# Altium schematic sheet NEO7M_EVAL.SchDoc
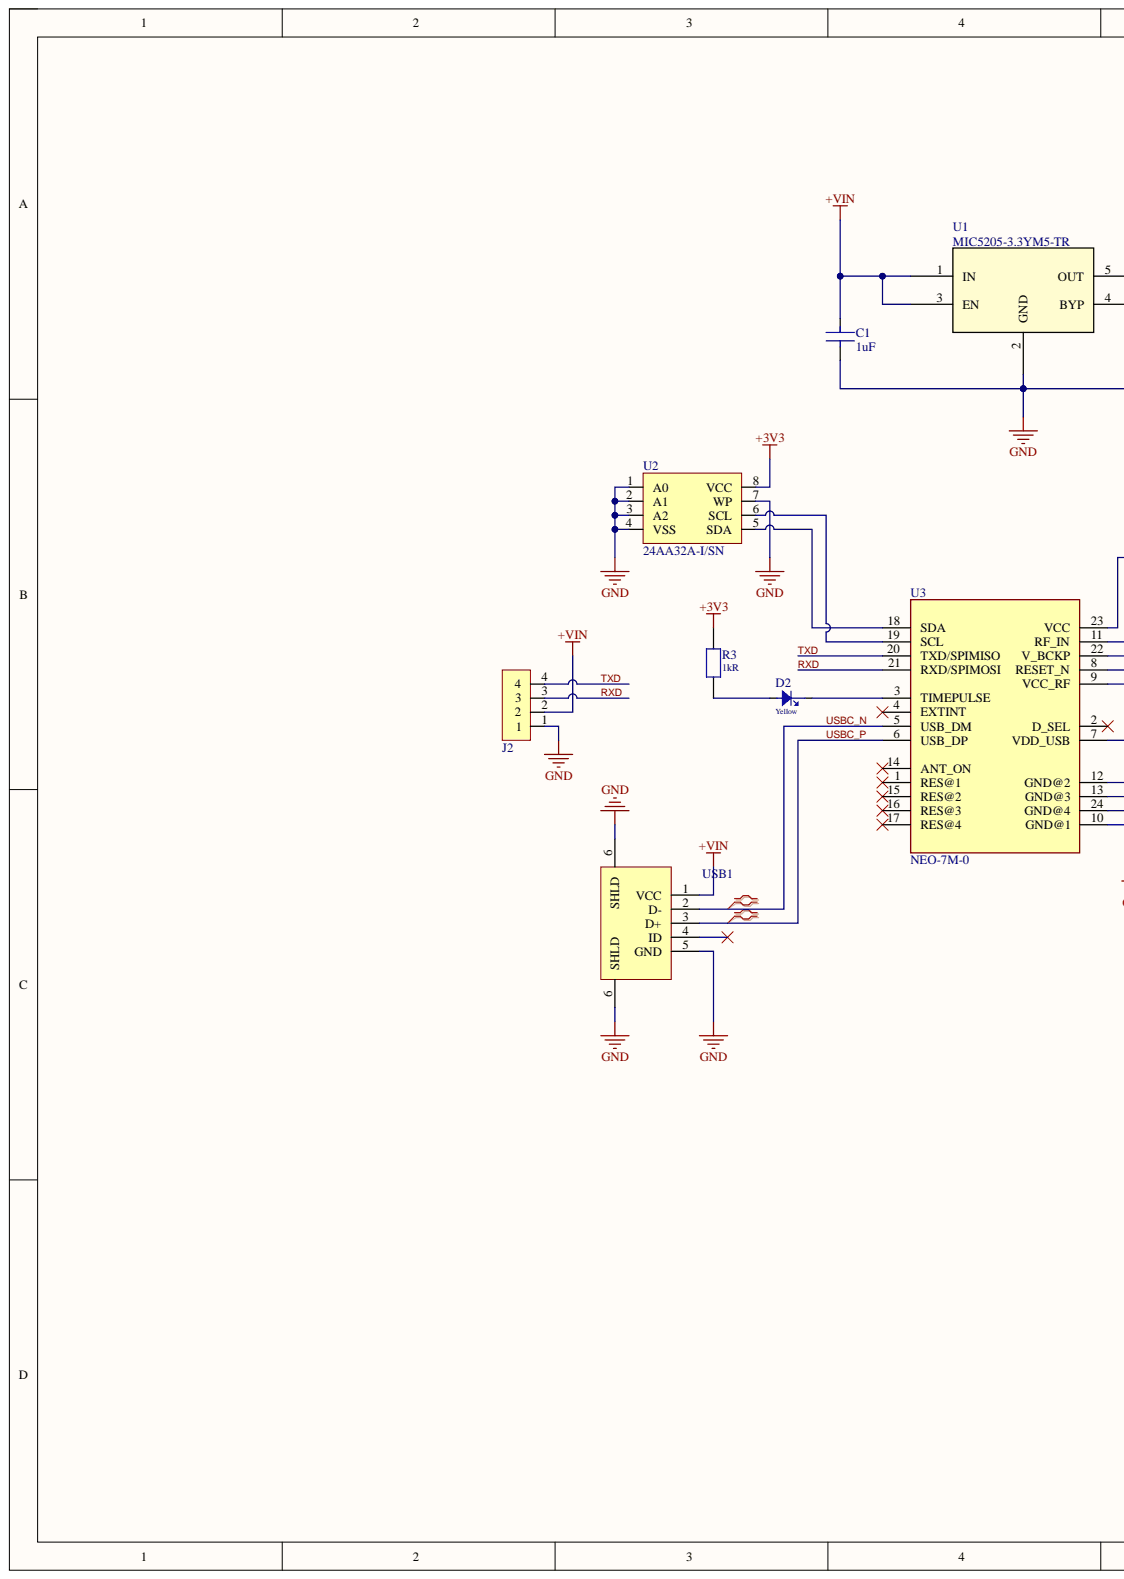
[diagram p0: NEO7M_EVAL.SchDoc, left]
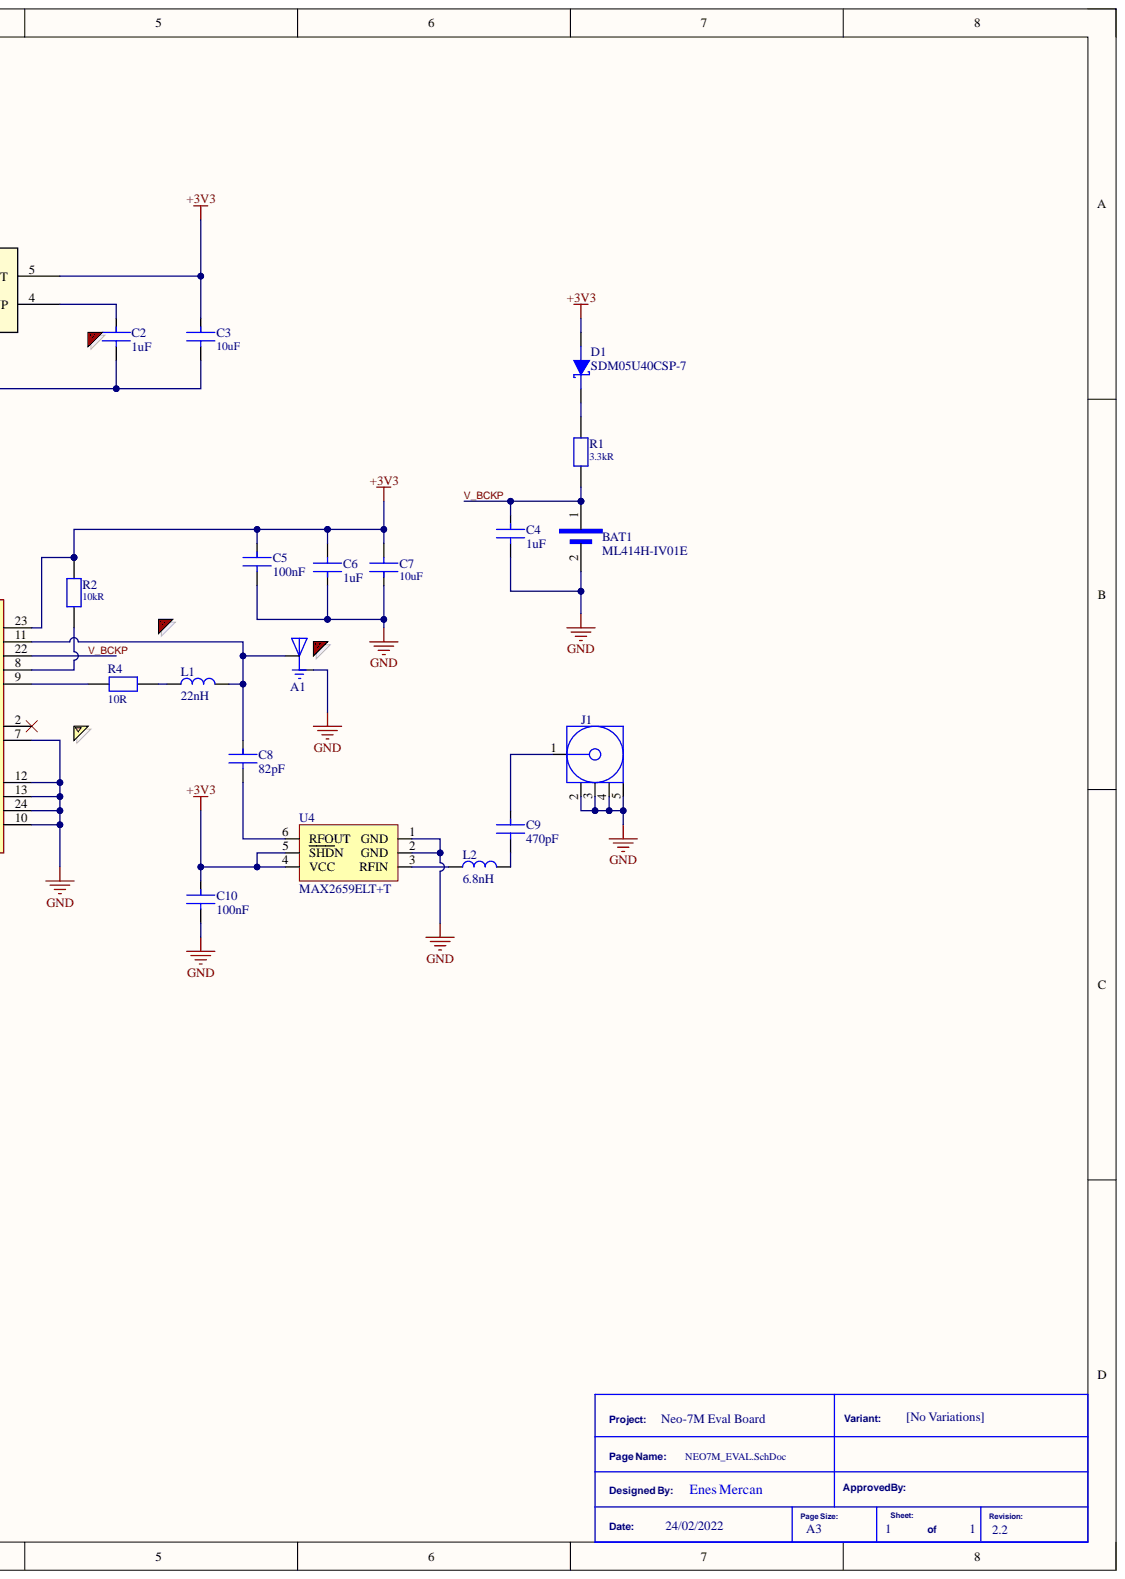
[diagram p1: NEO7M_EVAL.SchDoc, right]

## Parts
A1  U.FL-R-SMT-1(10)  [HRS_U.FL-R-SMT-1(10)]  (value not drawn)
BAT1  ML414H-IV01E  [ML414H-IV01E]
C1  Capacitance: 1uF  [CAP CER 0805]  (hidden comment: CL21B105KOFNFNE)
C2  Capacitance: 1uF  [CAP CER 0805]  (hidden comment: CL21B105KOFNFNE)
C3  Capacitance: 10uF  [CAP CER 0805]  (hidden comment: GRM21BR61E106MA73L)
C4  Capacitance: 1uF  [CAP CER 0805]  (hidden comment: CL21B105KOFNFNE)
C5  Capacitance: 100nF  [CAP CER 0603]  (hidden comment: C0603C104K5RAC7082)
C6  Capacitance: 1uF  [CAP CER 0805]  (hidden comment: CL21B105KOFNFNE)
C7  Capacitance: 10uF  [CAP CER 0805]  (hidden comment: GRM21BR61E106MA73L)
C8  Capacitance: 82pF  [CAP CER 0603]  (hidden comment: 06035A820FAT2A)
C9  value 470pF  [CAP CER 0603]  (hidden comment: C0603C471F8HAC7867)
C10  Capacitance: 100nF  [CAP CER 0603]  (hidden comment: C0603C104K5RAC7082)
D1  SDM05U40CSP-7  [SDM05U40CSP-7]
D2  Color: Yellow  [LED GR 0603]  (hidden comment: APTD1608LSYCK/J3-PF)
J1  60311002114501  [CONN SMA]  (value not drawn)
J2  M20-9730445  [HDR_ML_1X4]  (value not drawn)
L1  Inductance: 22nH  [IND 0603]  (hidden comment: LQW18AN22NG00D)
L2  Inductance: 6.8nH  [IND 0402]  (hidden comment: L-07C6N8JV6T)
R1  Resistance: 3.3kR  [RES 0603]  (hidden comment: RC0603FR-073K3L)
R2  Resistance: 10kR  [RES 0603]  (hidden comment: RC0603FR-0710KL)
R3  Resistance: 1kR  [RES 0603]  (hidden comment: RC0603FR-071KL)
R4  Resistance: 10R  [Res 0402]  (hidden comment: RK73H1ERTTP10R0F)
U1  MIC5205-3.3YM5-TR  [SOT-23-5]
U2  24AA32A-I/SN  [SOIC-8]
U3  NEO-7M-0  [NEO-7M-0]
U4  MAX2659ELT+T  [6-WFDFN]
USB1  10103592-0001LF  [10103592-0001LF]  (value not drawn)

## Nets
+3V3: C3.2 C5.2 C6.1 C7.1 C10.2 D1.A R2.2 R3.2 U1.5(OUT) U2.8(VCC) U3.23(VCC) U4.4(VCC) U4.5(SHDN)
+VIN: C1.2 J2.2 U1.1(IN) U1.3(EN) USB1.1(VCC)
GND: A1.GND BAT1.2 C1.1 C2.1 C3.1 C4.1 C5.1 C6.2 C7.2 C10.1 J1.2 J1.3 J1.4 J1.5 J2.1 U1.2(GND) U2.1(A0) U2.2(A1) U2.3(A2) U2.4(VSS) U2.7(WP) U3.7(VDD_USB) U3.10(GND@1) U3.12(GND@2) U3.13(GND@3) U3.24(GND@4) U4.1(GND) U4.2(GND) USB1.5(GND) USB1.6(SHLD)
RXD: J2.3 U3.21(RXD/SPIMOSI)
TXD: J2.4 U3.20(TXD/SPIMISO)
USBC_N: U3.5(USB_DM) USB1.2(D-)
USBC_P: U3.6(USB_DP) USB1.3(D+)
V_BCKP: BAT1.1 C4.2 R1.1 U3.22(V_BCKP)
NetA1_SIG: A1.SIG C8.2 L1.2 U3.11(RF_IN)
NetC2_2: C2.2 U1.4(BYP)
NetC8_1: C8.1 U4.6(RFOUT)
NetC9_1: C9.1 L2.1
NetC9_2: C9.2 J1.1
NetD1_C: D1.C R1.2
NetD2_1: D2.1 U3.3(TIMEPULSE)
NetD2_2: D2.2 R3.1
NetL1_1: L1.1 R4.1
NetL2_2: L2.2 U4.3(RFIN)
NetR2_1: R2.1 U3.8(RESET_N)
NetR4_2: R4.2 U3.9(VCC_RF)
NetU2_5: U2.5(SDA) U3.18(SDA)
NetU2_6: U2.6(SCL) U3.19(SCL)

Not connected: U3 1(RES@1) 2(D_SEL) 4(EXTINT) 14(ANT_ON) 15(RES@2) 16(RES@3) 17(RES@4); USB1 4(ID)

## Library data of the parts
A1 = U.FL-R-SMT-1(10): HIROSE(HRS) - U.FL-R-SMT-1(10) - RF COAXIAL, U.FL, STRAIGHT JACK, 50OHM; Body Material: Bronze; Body Plating: Silver; Connector Type: Coaxial,Receptacle,Male Pin; Dielectric Material: Polymer; Frequency: 6GHz; Gender: Male; Impedance: 50R; Insulation Resistance: 500MR; Category: RF / Coaxial Connectors; Component Kind: Standard; ComponentLink1Description: https://datasheet.ciiva.com/11762/0900766b8105243f-11762474.pdf?src-supplier=RSComponents; ComponentLink1URL: https://datasheet.ciiva.com/11762/0900766b8105243f-11762474.pdf?src-supplier=RSComponents; ComponentLink2Description: https://datasheet.ciiva.com/7651/91524-7651914.pdf?src-supplier=Element14; ComponentLink2URL: https://datasheet.ciiva.com/7651/91524-7651914.pdf?src-supplier=Element14; ComponentLink3Description: https://datasheet.ciiva.com/7651/91524-7651915.pdf?src-supplier=Element14; ComponentLink3URL: https://datasheet.ciiva.com/7651/91524-7651915.pdf?src-supplier=Element14; Contact Material: Brass,Bronze; Contact Plating: Gold; Depth: 3.1mm; Fastening Type: Snap; Housing Color: Beige; Lead Free: Lead Free; Length: 3mm; Library Name: MyLib.IntLib; Manufacturer: Hirose; Manufacturer Part Number: U.FL-R-SMT-1(10); Max Frequency: 6GHz; Max Operating Temperature: 90°C; Min Operating Temperature: -40°C; Mount: Surface Mount,Through Hole,PCB; Orientation: Straight; Package Quantity: 2500; Packaging: Tape and Reel; Pricing 1: 2500=0.62974, 5000=0.60802, 12500=0.56459 (USD); Radiation Hardening: No; REACH SVHC: No SVHC; RoHS: Yes; Stock 1: 24998; Supplier 1: Digi-Key; Supplier Part Number 1: H11891TR-ND; Termination: Solder; Wire/Cable Type: Coaxial
BAT1 = ML414H-IV01E: BATTERY LITHIUM 3V RECHARGE; Manufacturer: Seiko; Category: Batteries and Accessories; Component Kind: Standard; ComponentLink1Description: https://datasheet.ciiva.com/3/ml414h-e-3633.pdf?src-supplier=Digi-Key; ComponentLink1URL: https://datasheet.ciiva.com/3/ml414h-e-3633.pdf?src-supplier=Digi-Key; ComponentLink2Description: https://datasheet.ciiva.com/3/ml414h-e-3634.pdf?src-supplier=Digi-Key; ComponentLink2URL: https://datasheet.ciiva.com/3/ml414h-e-3634.pdf?src-supplier=Digi-Key; Library Name: My_SCH_Lib.SchLib; Manufacturer Part Number: ML414H-IV01E; Package Quantity: 1; Packaging: Tape and Reel; Pricing 1: 1=1.82000, 10=1.63000, 50=1.45000, 100=1.27000, 1000=0.96600, 10000=0.81500 (USD); RoHS: Yes; Stock 1: 5581; Supplier 1: Mouser; Supplier Part Number 1: 628-ML414H-IV01E; Termination: SMD/SMT; Voltage Rating: 3V; Weight: 0.007
C1 C2 C4 C6 = CAP CER 1UF 16V 0805: CAP CER 1UF 16V X7R 0805; Manufacturer: Samsung; Tolerance: 10%; Case Code (Imperial): 0805; Case Code (Metric): 2012; Case/Package: 0805; Category: Ceramic Capacitors; Component Kind: Standard; ComponentLink1Description: https://datasheet.ciiva.com/25713/cl21b105kofnfne-25713075.pdf?src-supplier=Digi-Key; ComponentLink1URL: https://datasheet.ciiva.com/25713/cl21b105kofnfne-25713075.pdf?src-supplier=Digi-Key; Construction: Flat; Contact Plating: Tin; Dielectric: X7R; Length: 0.079inch; Library Name: My_SCH_Lib.SchLib; Manufacturer Part Number: CL21B105KOFNFNE; Max Operating Temperature: 125°C; Min Operating Temperature: -55°C; Mount: Surface Mount; Package Quantity: 1; Packaging: Tape and Reel; Pricing 1: 1=0.12000, 10=0.08900, 50=0.04840, 100=0.03940, 500=0.02818, 1000=0.02214 (USD); RoHS: Yes; RoHS Compliant: Yes; Series: CL; Stock 1: 73789; Supplier 1: Digi-Key; Supplier Part Number 1: 1276-2930-1-ND; Termination: Wraparound; Thickness: 0.053inch; Voltage Rating: 16V; Width: 0.049inch
C3 C7 = CAP CER 10UF 25V 0805: MURATA - GRM21BR61E106MA73L - SMD Multilayer Ceramic Capacitor, 10 µF, 25 V, 0805 [2012 Metric], ± 20%, X5R, GRM Series; Voltage Rating: 25V; Case Code (Imperial): 0805; Case Code (Metric): 2012; Case/Package: 0805; Category: Ceramic Capacitors; Component Kind: Standard; ComponentLink1Description: http://www.farnell.com/datasheets/2079185.pdf?src-supplier=Farnell; ComponentLink1URL: http://www.farnell.com/datasheets/2079185.pdf?src-supplier=Farnell; ComponentLink2Description: http://www.mouser.com/ds/2/281/c02e-2905.pdf?src-supplier=Mouser; ComponentLink2URL: http://www.mouser.com/ds/2/281/c02e-2905.pdf?src-supplier=Mouser; ComponentLink3Description: http://components.arrow.com/part/dataview/0323_03061623?src-supplier=Arrow+Europe; ComponentLink3URL: http://components.arrow.com/part/dataview/0323_03061623?src-supplier=Arrow+Europe; ComponentLink4Description: https://search.murata.co.jp/Ceramy/image/img/A01X/G101/ENG/GRM21BR61E106MA73-01.pdf?src-supplier=Digi-Key; ComponentLink4URL: https://search.murata.co.jp/Ceramy/image/img/A01X/G101/ENG/GRM21BR61E106MA73-01.pdf?src-supplier=Digi-Key; ComponentLink5Description: http://www.farnell.com/datasheets/2079185.pdf?src-supplier=Element14; ComponentLink5URL: http://www.farnell.com/datasheets/2079185.pdf?src-supplier=Element14; Depth: 1.25mm; Dielectric: X5R; Height: 1.25mm; Lead Free: Lead Free; Length: 2mm; Library Name: My_SCH_Lib.SchLib; Manufacturer: Murata; Manufacturer Part Number: GRM21BR61E106MA73L; Max Operating Temperature: 85°C; Min Operating Temperature: -55°C; Mount: Surface Mount; Package Quantity: 3000; Packaging: Tape and Reel; Pricing 1: 1=0.26000, 10=0.17900, 50=0.11960, 100=0.10170, 500=0.07176, 1000=0.06279 (USD); REACH SVHC: No SVHC; Resistor Type: Ceramic; RoHS: Yes; RoHS Compliant: Yes; Stock 1: 318119; Supplier 1: Digi-Key; Supplier Part Number 1: 490-10748-1-ND; Termination: SMD/SMT; Thickness: 0.055inch; Tolerance: 20%; Voltage Rating (DC): 25V; Weight: 0.000335oz; Width: 0.049inch
C5 C10 = CAP CER 0.1UF 50V 0603: Cap Cer 0.1uF 50V 0603 10%; Manufacturer: KEMET; DesignedBy: ENES MERCAN; Dielectric: X7R; Dielectric Material: Ceramic; Case/Package: 0603; Category: Ceramic Capacitors; Component Kind: Standard; Manufacturer Part Number: C0603C104K5RAC7082; Pricing 1: 1=0.12000, 10=0.08900, 50=0.04840, 100=0.03940, 500=0.02818, 1000=0.02214, 2500=0.02012, 5000=0.01852 (USD); RoHS: Yes; RoHS Compliant: Yes; Stock 1: 44787; Supplier 1: Digi-Key; Supplier Part Number 1: 399-C0603C104K5RAC7082CT-ND; Tolerance: 10%; Voltage Rating (DC): 50V; Weight: 0.063
  C5: Library Name: My_SCH_Lib.SchLib
  C10: Library Name: MyLib.IntLib
C8 = CAP CER 0.082UF 50V 0603: 0603 82 pF 50 V ±1% Tolerance C0G/NP0 Surface Mount Multilayer Ceramic Capacitor; Manufacturer: Kyocera AVX; Dielectric: C0G; Voltage Rating: 50V; Case Code (Imperial): 0603; Case Code (Metric): 1608; Case/Package: 0603; Category: Ceramic Capacitors; Component Kind: Standard; ComponentLink1Description: https://datasheet.ciiva.com/209/ccog-209702.pdf?src-supplier=Digi-Key; ComponentLink1URL: https://datasheet.ciiva.com/209/ccog-209702.pdf?src-supplier=Digi-Key; ComponentLink2Description: https://datasheet.ciiva.com/17492/ccog-17492203.pdf?src-supplier=Avnet+Europe; ComponentLink2URL: https://datasheet.ciiva.com/17492/ccog-17492203.pdf?src-supplier=Avnet+Europe; ComponentLink3Description: https://datasheet.ciiva.com/5423/ccog-5423484.pdf?src-supplier=Mouser; ComponentLink3URL: https://datasheet.ciiva.com/5423/ccog-5423484.pdf?src-supplier=Mouser; ComponentLink4Description: https://datasheet.ciiva.com/11567/ccog-11567405.pdf?src-supplier=Avnet; ComponentLink4URL: https://datasheet.ciiva.com/11567/ccog-11567405.pdf?src-supplier=Avnet; Construction: Flat; Contact Plating: Tin,Matte; Depth: 0.81mm; Lead Free: Lead Free; Length: 1.6mm; Library Name: My_SCH_Lib.SchLib; Manufacturer Part Number: 06035A820FAT2A; Max Operating Temperature: 125°C; Min Operating Temperature: -55°C; Mount: Surface Mount; Package Quantity: 4000; Packaging: Tape and Reel; Pricing 1: 1=0.24000, 10=0.16500, 50=0.09900, 100=0.08360, 500=0.05720, 1000=0.05060 (USD); Radiation Hardening: No; RoHS: Yes; RoHS Compliant: Yes; Series: -; Stock 1: 38668; Supplier 1: Digi-Key; Supplier Part Number 1: 478-10339-1-ND; Termination: SMD/SMT; Thickness: 0.035inch; Tolerance: 1%; Voltage Rating (DC): 50V; Weight: 7.1E-05oz; Width: 0.032inch
C9 = CAP CER 0.47UF 10V 0603: Cap Cer 0603 470PF 10V Ultra Sta; Manufacturer: KEMET; Category: Ceramic Capacitors; Component Kind: Standard; Library Name: My_SCH_Lib.SchLib; Manufacturer Part Number: C0603C471F8HAC7867; Pricing 1: 1=0.17000, 10=0.12300, 50=0.06980, 100=0.05790, 500=0.04060, 1000=0.03361 (USD); RoHS: No; Stock 1: 9092; Supplier 1: Digi-Key; Supplier Part Number 1: 399-C0603C471F8HAC7867CT-ND
D1 = Schottky Diode GPS: DIODE SCHTKY 40V 500MA X3WLB1006; Manufacturer: Diodes; Capacitance: 3.5E-11F; Element Configuration: Single; Forward Voltage: 460mV; Max Forward Surge Current (Ifsm): 14A; Max Reverse Current: 75uA; Average Rectified Current: 500mA; Category: Rectifier Diodes; Component Kind: Standard; ComponentLink1Description: http://www.mouser.com/ds/2/115/SDM05U40CSP-520315.pdf?src-supplier=Mouser; ComponentLink1URL: http://www.mouser.com/ds/2/115/SDM05U40CSP-520315.pdf?src-supplier=Mouser; ComponentLink2Description: https://www.diodes.com/assets/Datasheets/SDM05U40CSP.pdf?src-supplier=Digi-Key; ComponentLink2URL: https://www.diodes.com/assets/Datasheets/SDM05U40CSP.pdf?src-supplier=Digi-Key; Forward Current: 500mA; Library Name: MyLib.IntLib; Manufacturer Part Number: SDM05U40CSP-7; Max Operating Temperature: 150°C; Max Repetitive Reverse Voltage (Vrrm): 40V; Max Reverse Voltage (DC): 40V; Min Operating Temperature: -55°C; Mount: Surface Mount; Number of Pins: 2; Package Quantity: 5000; Packaging: Tape and Reel; Pricing 1: 1=0.41000, 10=0.30800, 100=0.17440, 500=0.11550, 1000=0.08855, 2000=0.07700 (USD); Reverse Voltage (DC): 40V; RoHS: Yes; RoHS Compliant: Yes; Stock 1: 221856; Supplier 1: Digi-Key; Supplier Part Number 1: SDM05U40CSP-7DIDKR-ND; Weight: 0.4
D2 = LED YLW 0603: LED YELLOW CLEAR 2SMD; Manufacturer: Kingbright; Dominant Wavelength: 590nm; Forward Voltage: 1.85V; Lens Color: Colorless; Luminous Intensity: 55mcd; Test Current: 2mA; Viewing Angle: 60°; Case Code (Imperial): 0603; Case Code (Metric): 1608; Case/Package: 0603; Category: LEDs; Component Kind: Standard; ComponentLink1Description: http://www.mouser.com/ds/2/216/APTD1608LSYCK-J3-PF-535613.pdf?src-supplier=Mouser; ComponentLink1URL: http://www.mouser.com/ds/2/216/APTD1608LSYCK-J3-PF-535613.pdf?src-supplier=Mouser; ComponentLink2Description: http://www.kingbrightusa.com/images/catalog/SPEC/APTD1608LSYCK-J3-PF.pdf?src-supplier=Digi-Key; ComponentLink2URL: http://www.kingbrightusa.com/images/catalog/SPEC/APTD1608LSYCK-J3-PF.pdf?src-supplier=Digi-Key; Height: 0.95mm; Length: 1.6mm; Lens Transparency: Clear; Library Name: MyLib.IntLib; Manufacturer Part Number: APTD1608LSYCK/J3-PF; Mount: Surface Mount; Packaging: Tape and Reel; Pricing 1: 1=0.58000, 10=0.38200, 100=0.25300, 1000=0.19532 (USD); RoHS: Yes; RoHS Compliant: No; Stock 1: 32340; Supplier 1: Digi-Key; Supplier Part Number 1: 754-1947-1-ND; Weight: 0.0025; Width: 0.8mm
J1 = CONN SMA FML: Rf Coax Conn, Sma R/a Jack, 50 Ohm, Pcb Rohs Compliant: Yes; Manufacturer: Wurth Electronics; Category: RF / Coaxial Connectors; Component Kind: Standard; Library Name: My_SCH_Lib.SchLib; Manufacturer Part Number: 60311002114501; Pricing 1: 1=10.36000, 25=9.92400, 60=9.05900, 120=8.19600, 180=7.33400 (USD); RoHS: Yes; Stock 1: 344; Supplier 1: Digi-Key; Supplier Part Number 1: 732-13833-ND
J2 = HDR_ML_1X4: Headers & Wire Housings 04 SIL VERTICAL PIN HEADER GOLD HT; Manufacturer: Harwin; Connector Type: Male Pin; Contact Resistance: 25mR; Current Rating: 3A; Gender: Male; Number of Contacts: 4; Pitch: 2.54mm; Voltage Rating: 750V; Case/Package: SIL; Category: Headers and Wire Housings; Color: Black; Component Kind: Standard; ComponentLink1Description: https://datasheet.ciiva.com/16733/m20-973-16733567.pdf?src-supplier=Avnet+Europe; ComponentLink1URL: https://datasheet.ciiva.com/16733/m20-973-16733567.pdf?src-supplier=Avnet+Europe; Contact Material: Brass; Contact Mating Length: 0.276inch; Contact Plating: Gold; Contact Type: Male Pin; Depth: 2.54mm; Housing Color: Black; Housing Material: Nylon; Length: 10.16mm; Library Name: MyLib.IntLib; Manufacturer Part Number: M20-9730445; Max Current Rating: 3A; Max Operating Temperature: 105°C; Min Operating Temperature: -40°C; Mount: Through Hole; Number of Positions: 4; Number of Rows: 1; Orientation: Straight; Package Quantity: 1000; Packaging: Bulk; Pricing 1: 1=0.25000, 10=0.23900, 100=0.16540, 500=0.13998, 1000=0.11453, 5000=0.10181, 10000=0.09226 (USD); Radiation Hardening: No; RoHS: Yes; RoHS Compliant: Yes; Series: M20; Stock 1: 2723; Supplier 1: Digi-Key; Supplier Part Number 1: 952-3273-ND; Termination: Solder; Weight: 0.244
L1 = INF 27nH 0603: IND 22NH 500MA 170MOHM SMD 22nH 0.5A ±2% 0603; Manufacturer: Murata; Core Material: Non-Magnetic; Current: 400mA; DC Resistance (DCR): 170mR; Q Factor: 40; Self Resonant Frequency: 4.6GHz; Shielding: Unshielded; Test Frequency: 100MHz; Case Code (Imperial): 0603; Case Code (Metric): 1608; Case/Package: 0603; Category: Fixed Inductors; Component Kind: Standard; ComponentLink1Description: https://datasheet.ciiva.com/11799/0900766b80a002db-11799279.pdf?src-supplier=RSComponents; ComponentLink1URL: https://datasheet.ciiva.com/11799/0900766b80a002db-11799279.pdf?src-supplier=RSComponents; ComponentLink2Description: https://datasheet.ciiva.com/14124/qhlqw18a-data-e-14124619.pdf?src-supplier=Distrelec; ComponentLink2URL: https://datasheet.ciiva.com/14124/qhlqw18a-data-e-14124619.pdf?src-supplier=Distrelec; ComponentLink3Description: https://datasheet.ciiva.com/6705/l0075s0080lqw18a-00-6705627.pdf?src-supplier=Digi-Key; ComponentLink3URL: https://datasheet.ciiva.com/6705/l0075s0080lqw18a-00-6705627.pdf?src-supplier=Digi-Key; ComponentLink4Description: https://datasheet.ciiva.com/6705/l0075s0080lqw18a-00-6705626.pdf?src-supplier=Digi-Key; ComponentLink4URL: https://datasheet.ciiva.com/6705/l0075s0080lqw18a-00-6705626.pdf?src-supplier=Digi-Key; ComponentLink5Description: https://datasheet.ciiva.com/6705/l0075s0080lqw18a-00-6705631.pdf?src-supplier=Digi-Key; ComponentLink5URL: https://datasheet.ciiva.com/6705/l0075s0080lqw18a-00-6705631.pdf?src-supplier=Digi-Key; ComponentLink6Description: https://datasheet.ciiva.com/4977/product-4977173.pdf?src-supplier=Mouser; ComponentLink6URL: https://datasheet.ciiva.com/4977/product-4977173.pdf?src-supplier=Mouser; ComponentLink7Description: https://datasheet.ciiva.com/46391/1487239-46391853.pdf?src-supplier=Element14; ComponentLink7URL: https://datasheet.ciiva.com/46391/1487239-46391853.pdf?src-supplier=Element14; ComponentLink8Description: https://datasheet.ciiva.com/8507/l0075s0080lqw18a-00-8507496.pdf?src-supplier=Avnet; ComponentLink8URL: https://datasheet.ciiva.com/8507/l0075s0080lqw18a-00-8507496.pdf?src-supplier=Avnet; Current Rating: 500mA; DC Current: 500mA; Depth: 1mm; Height: 0.8mm; Height - Seated (Max): 0.039inch; Inductance Tolerance: 2%; Lead Free: Lead Free; Length: 1.6mm; Library Name: My_SCH_Lib.SchLib; Manufacturer Part Number: LQW18AN22NG00D; Max DC Current: 500mA; Max Operating Temperature: 125°C; Military Standard: Not; Min Operating Temperature: -55°C; Mount: Surface Mount; Number of Pins: 2; Package Quantity: 4000; Packaging: Tape and Reel; Pricing 1: 10=0.18100, 500=0.12100, 1000=0.11100, 2000=0.10300, 5000=0.09200 (USD); Rated Current-Max: 500mA; REACH SVHC: No SVHC; Resistor Type: Wirewound; RoHS: Yes; RoHS Compliant: Yes; Series: LQW18A_00; Series Resistance: 170mR; Stock 1: 14900; Supplier 1: RSComponents; Supplier Part Number 1: 7242933; Termination: SMD/SMT; Tolerance: 2%; Weight: 0.000106oz; Width: 0.8mm
L2 = IND 6.8nH 0402: Inductor RF Chip Multi-Layer 0.0068uH 5% 100MHz 8Q-Factor Ceramic 0.25A 0.32Ohm DCR 0402 T/R; Manufacturer: Johanson; Current Rating: 250mA; DC Resistance (DCR): 320mR; Q Factor: 8; Self Resonant Frequency: 5GHz; Shielding: Unshielded; Case Code (Imperial): 0402; Case Code (Metric): 1005; Case/Package: 0402; Category: Fixed Inductors; Component Kind: Standard; ComponentLink1Description: http://components.arrow.com/part/dataview/43912090S93548N2069?src-supplier=Arrow+Electronics; ComponentLink1URL: http://components.arrow.com/part/dataview/43912090S93548N2069?src-supplier=Arrow+Electronics; ComponentLink2Description: https://media.digikey.com/pdf/Data%20Sheets/Johanson%20Technology/RF_Ceramic_Chip_Inductors.pdf?src-supplier=Digi-Key; ComponentLink2URL: https://media.digikey.com/pdf/Data%20Sheets/Johanson%20Technology/RF_Ceramic_Chip_Inductors.pdf?src-supplier=Digi-Key; ComponentLink3Description: http://www.mouser.com/ds/2/611/JTI_CAT_2012_Inductors_Chip-242427.pdf?src-supplier=Mouser; ComponentLink3URL: http://www.mouser.com/ds/2/611/JTI_CAT_2012_Inductors_Chip-242427.pdf?src-supplier=Mouser; ComponentLink4Description: http://www.farnell.com/datasheets/1647600.pdf?src-supplier=Farnell; ComponentLink4URL: http://www.farnell.com/datasheets/1647600.pdf?src-supplier=Farnell; ComponentLink5Description: http://components.arrow.com/part/dataview/0323_01333927?src-supplier=Arrow+Europe; ComponentLink5URL: http://components.arrow.com/part/dataview/0323_01333927?src-supplier=Arrow+Europe; ComponentLink6Description: https://www.johansontechnology.com/downloads/jti-cat-rf-ind.pdf?src-supplier=Digi-Key; ComponentLink6URL: https://www.johansontechnology.com/downloads/jti-cat-rf-ind.pdf?src-supplier=Digi-Key; ComponentLink7Description: http://www.farnell.com/datasheets/1327494.pdf?src-supplier=Element14; ComponentLink7URL: http://www.farnell.com/datasheets/1327494.pdf?src-supplier=Element14; ComponentLink8Description: http://www.johansontechnology.com/images/stories/rf-inductors/cci/JTI_CAT_2012_Inductors_Chip.pdf?src-supplier=Avnet; ComponentLink8URL: http://www.johansontechnology.com/images/stories/rf-inductors/cci/JTI_CAT_2012_Inductors_Chip.pdf?src-supplier=Avnet; Core Material: Ceramic; DC Current: 250mA; Depth: 0.6mm; Height: 0.5mm; Height - Seated (Max): 0.024inch; Inductance Tolerance: 5%; Length: 0.039inch; Library Name: My_SCH_Lib.SchLib; Manufacturer Part Number: L-07C6N8JV6T; Max DC Current: 250mA; Max Operating Temperature: 100°C; Military Standard: Not; Min Operating Temperature: -40°C; Mount: Surface Mount; Number of Pins: 2; Package Quantity: 1; Packaging: Tape and Reel; Pricing 1: 1=0.11000, 10=0.04000, 25=0.03700, 50=0.03300, 100=0.03000 (USD); Radiation Hardening: No; REACH SVHC: No SVHC; RoHS: Yes; RoHS Compliant: Yes; Series: -; Stock 1: 38232; Supplier 1: Newark; Supplier Part Number 1: 39T4607; Termination: SMD/SMT; Test Frequency: 100MHz; Tolerance: 5%; Width: 0.02inch
R1 = RES 3K3R 0603: YAGEO - RC0603FR-073K3L - SMD Chip Resistor, 0603 [1608 Metric], 3.3 kohm, RC Series, 75 V, Thick Film, 100 mW; Max Power Dissipation: 100mW; Resistance Tolerance: 1%; Temperature Coefficient: 100ppm/°C; Voltage Rating: 50V; Case Code (Imperial): 0603; Case Code (Metric): 1608; Case/Package: 0603; Category: Chip SMD Resistors; Component Kind: Standard; Construction: Rectangular; Depth: 0.8mm; Features: Moisture Resistant; Height: 0.022inch; Lead Free: Lead Free; Length: 1.6mm; Library Name: MyLib.IntLib; Manufacturer: Yageo; Manufacturer Part Number: RC0603FR-073K3L; Max Operating Temperature: 155°C; Military Standard: Not; Min Operating Temperature: -55°C; Number of Pins: 2; Number of Terminations: 2; Package Quantity: 1; Packaging: Tape and Reel; Power Rating: 100mW; Pricing 1: 1=0.10000, 10=0.02400, 100=0.00970, 1000=0.00436, 2500=0.00378 (USD); REACH SVHC: No SVHC; Resistor Type: Thick Film; RoHS: Yes; RoHS Compliant: Yes; Series: RC; Size Code: 0603; Stock 1: 789417; Supplier 1: Digi-Key; Supplier Part Number 1: 311-3.30KHRDKR-ND; Termination: SMD/SMT; Tolerance: 1%; Voltage Rating (DC): 50V; Weight: 0.016; Width: 0.8mm
R2 = RES 10K 0603: Res General Purpose Thick Film 0603 10K Ohm 1% 1/10W ±100ppm/°C Molded SMD Paper T/R; Manufacturer: Yageo; Max Power Dissipation: 100mW; Voltage Rating: 75V; Voltage Rating (DC): 50V; Case Code (Imperial): 0603; Case Code (Metric): 1608; Case/Package: 0603; Category: Chip SMD Resistors; Component Kind: Standard; ComponentLink1Description: https://datasheet.ciiva.com/7660/38590-7660789.pdf?src-supplier=Element14; ComponentLink1URL: https://datasheet.ciiva.com/7660/38590-7660789.pdf?src-supplier=Element14; ComponentLink2Description: https://datasheet.ciiva.com/7983/443971-7983596.pdf?src-supplier=Element14; ComponentLink2URL: https://datasheet.ciiva.com/7983/443971-7983596.pdf?src-supplier=Element14; ComponentLink3Description: https://datasheet.ciiva.com/22747/443971-22747519.pdf?src-supplier=Element14; ComponentLink3URL: https://datasheet.ciiva.com/22747/443971-22747519.pdf?src-supplier=Element14; ComponentLink4Description: https://datasheet.ciiva.com/46440/1868787-46440891.pdf?src-supplier=Element14; ComponentLink4URL: https://datasheet.ciiva.com/46440/1868787-46440891.pdf?src-supplier=Element14; ComponentLink5Description: https://datasheet.ciiva.com/7746/1738695-7746239.pdf?src-supplier=Element14; ComponentLink5URL: https://datasheet.ciiva.com/7746/1738695-7746239.pdf?src-supplier=Element14; ComponentLink6Description: https://datasheet.ciiva.com/15189/getdatasheetpartid-409289-15189591.pdf?src-supplier=Verical; ComponentLink6URL: https://datasheet.ciiva.com/15189/getdatasheetpartid-409289-15189591.pdf?src-supplier=Verical; Construction: Rectangular; Depth: 0.8mm; Features: Moisture Resistant; Height: 0.45mm; Lead Free: Lead Free; Lead Pitch: 0.3mm; Length: 1.6mm; Library Name: MyLib.IntLib; Manufacturer Part Number: RC0603FR-0710KL; Max Operating Temperature: 155°C; Military Standard: Not; Min Operating Temperature: -55°C; Number of Pins: 2; Number of Terminations: 2; Packaging: Tape and Reel; Power Rating: 100mW; Pricing 1: 1=0.10000, 10=0.02400, 100=0.00970, 1000=0.00436, 2500=0.00378 (USD); REACH SVHC: Unknown; Resistance Tolerance: 1%; Resistor Type: Thick Film; RoHS: Yes; RoHS Compliant: Yes; Series: RC; Size Code: 0603; Stock 1: 17970648; Supplier 1: Digi-Key; Supplier Part Number 1: 311-10.0KHRCT-ND; Temperature Coefficient: 100ppm/°C; Termination: SMD/SMT; Tolerance: 1%; Weight: 0.002; Width: 0.8mm
R3 = RES 1KR 0603: RES SMD 1K OHM 1% 1/10W 0603; Manufacturer: Yageo; Max Power Dissipation: 100mW; Temperature Coefficient: 100ppm/°C; Voltage Rating: 50V; Case Code (Imperial): 0603; Case Code (Metric): 1608; Case/Package: 0603; Category: Chip SMD Resistors; Component Kind: Standard; Construction: Rectangular; Contact Plating: Tin,Matte; Depth: 0.8mm; Features: Moisture Resistant; Height: 0.45mm; Lead Free: Lead Free; Length: 1.6mm; Library Name: MyLib.IntLib; Manufacturer Part Number: RC0603FR-071KL; Max Operating Temperature: 155°C; Military Standard: Not; Min Operating Temperature: -55°C; Number of Terminations: 2; Packaging: Tape and Reel; Power Rating: 100mW; Pricing 1: 1=0.10000, 10=0.02400, 100=0.00970, 1000=0.00436, 2500=0.00378 (USD); Radiation Hardening: No; REACH SVHC: No SVHC; Resistance Tolerance: 1%; Resistor Type: Thick Film; RoHS: Yes; RoHS Compliant: Yes; Series: RC; Size Code: 0603; Stock 1: 12963545; Supplier 1: Digi-Key; Supplier Part Number 1: 311-1.00KHRCT-ND; Technology: THICK FILM; Termination: SMD/SMT; Tolerance: 1%; Weight: 0.016
R4 = RES 10R 0402: Thick Film Resistors - SMD Anti Sulfur 0.5 Tol. 0402 -55oC to 155oC; Manufacturer: KOA Speer; Power Rating: 63mW; Temperature Coefficient: 100ppm/°C; Tolerance: 1%; Voltage Rating: 50V; Case Code (Imperial): 0402; Case Code (Metric): 1005; Case/Package: 0402; Category: Chip SMD Resistors; Component Kind: Standard; ComponentLink1Description: http://www.mouser.com/ds/2/219/RK73H-5715.pdf?src-supplier=Mouser; ComponentLink1URL: http://www.mouser.com/ds/2/219/RK73H-5715.pdf?src-supplier=Mouser; Height: 0.35mm; Length: 1mm; Library Name: MyLib.IntLib; Manufacturer Part Number: RK73H1ERTTP10R0F; Max Operating Temperature: 155°C; Min Operating Temperature: -55°C; Package Quantity: 10000; Packaging: Tape and Reel; Pricing 1: 1=0.14000, 10=0.12700, 100=0.04890, 1000=0.02150, 2500=0.01857, 5000=0.01662 (USD); RoHS: Yes; Stock 1: 108727; Supplier 1: Digi-Key; Supplier Part Number 1: 2019-RK73H1ERTTP10R0FCT-ND; Termination: SMD/SMT; Weight: 2.3E-05oz; Width: 0.5mm
U1 = MIC5205-3.3YM5-TR: Linear Voltage Regulator IC Positive Fixed 1 Output 3.3V 150mA SOT-23-5; Manufacturer: Microchip; Dropout Voltage: 165mV; Max Input Voltage: 16V; Min Input Voltage: 2.5V; Number of Outputs: 1; Case/Package: SOT-23-5; Category: Voltage Regulators - Linear; Component Kind: Standard; ComponentLink1Description: http://www.farnell.com/datasheets/29605.pdf?src-supplier=Farnell; ComponentLink1URL: http://www.farnell.com/datasheets/29605.pdf?src-supplier=Farnell; ComponentLink2Description: http://uk.rs-online.com/webdocs/0add/0900766b80add540.pdf?src-supplier=RSComponents; ComponentLink2URL: http://uk.rs-online.com/webdocs/0add/0900766b80add540.pdf?src-supplier=RSComponents; ComponentLink3Description: http://www.mouser.com/ds/2/268/mic5205-778789.pdf?src-supplier=Mouser; ComponentLink3URL: http://www.mouser.com/ds/2/268/mic5205-778789.pdf?src-supplier=Mouser; ComponentLink4Description: http://www.farnell.com/datasheets/29605.pdf?src-supplier=Element14; ComponentLink4URL: http://www.farnell.com/datasheets/29605.pdf?src-supplier=Element14; ComponentLink5Description: http://www.farnell.com/datasheets/29605.pdf?src-supplier=Newark; ComponentLink5URL: http://www.farnell.com/datasheets/29605.pdf?src-supplier=Newark; ComponentLink6Description: http://www.microchip.com/mymicrochip/filehandler.aspx?ddocname=en579613&src-supplier=Digi-Key; ComponentLink6URL: http://www.microchip.com/mymicrochip/filehandler.aspx?ddocname=en579613&src-supplier=Digi-Key; Lead Free: Lead Free; Library Name: My_SCH_Lib.SchLib; Manufacturer Part Number: MIC5205-3.3YM5-TR; Max Operating Temperature: 125°C; Min Operating Temperature: -40°C; Mount: Surface Mount; Nominal Output Voltage: 3.3V; Number of Pins: 5; Number of Regulators: 1; Output Current: 150mA; Output Type: Fixed; Output Voltage: 3.3V; Packaging: Cut Tape; Pricing 1: 1=0.49000, 25=0.41360, 100=0.37100 (USD); REACH SVHC: No SVHC; RoHS: Yes; RoHS Compliant: Yes; Supplier 1: Digi-Key; Supplier Part Number 1: 576-1259-1-ND
U2 = 24AA32A-I/SN: EEPROM Serial-2Wire 32K-bit 4K x 8 1.8V/2.5V/3.3V/5V 8-Pin SOIC N Tube; Manufacturer: Microchip; Access Time: 900ns; Density: 32Kb; Frequency: 400kHz; Interface: I2C,Serial,2-Wire; Max Supply Voltage: 5.5V; Min Supply Voltage: 1.8V; Nominal Supply Current: 3mA; Case/Package: SOIC; Category: EEPROM; Component Kind: Standard; ComponentLink1Description: https://datasheet.ciiva.com/15123/getdatasheetpartid-765132-15123737.pdf?src-supplier=Verical; ComponentLink1URL: https://datasheet.ciiva.com/15123/getdatasheetpartid-765132-15123737.pdf?src-supplier=Verical; ComponentLink2Description: https://datasheet.ciiva.com/8059/1669362-8059094.pdf?src-supplier=Element14; ComponentLink2URL: https://datasheet.ciiva.com/8059/1669362-8059094.pdf?src-supplier=Element14; ComponentLink3Description: https://datasheet.ciiva.com/16622/21713l-16622938.pdf?src-supplier=Avnet+Europe; ComponentLink3URL: https://datasheet.ciiva.com/16622/21713l-16622938.pdf?src-supplier=Avnet+Europe; ComponentLink4Description: https://datasheet.ciiva.com/1154/1669362-1154811.pdf?src-supplier=Element14; ComponentLink4URL: https://datasheet.ciiva.com/1154/1669362-1154811.pdf?src-supplier=Element14; ComponentLink5Description: https://datasheet.ciiva.com/16922/70045247-16922204.pdf?src-supplier=Allied+Electronics; ComponentLink5URL: https://datasheet.ciiva.com/16922/70045247-16922204.pdf?src-supplier=Allied+Electronics; ComponentLink6Description: https://datasheet.ciiva.com/41454/001085241-da-01-en-ic-eeprom-32kbit-24aa32a-i-sn-soic-8-mcp-41454939.pdf?src-supplier=Conrad; ComponentLink6URL: https://datasheet.ciiva.com/41454/001085241-da-01-en-ic-eeprom-32kbit-24aa32a-i-sn-soic-8-mcp-41454939.pdf?src-supplier=Conrad; Contact Plating: Tin,Matte; Height: 1.5mm; Lead Free: Lead Free; Length: 4.9mm; Library Name: My_SCH_Lib.SchLib; Manufacturer Part Number: 24AA32A-I/SN; Max Frequency: 400kHz; Max Operating Temperature: 85°C; Memory Size: 32Kb; Memory Type: EEPROM; Min Operating Temperature: -40°C; Mount: Surface Mount; Number of Pins: 8; Package Quantity: 100; Packaging: Rail/Tube; Pricing 1: 1=0.50000 (USD); Radiation Hardening: No; REACH SVHC: No SVHC; RoHS: Yes; Stock 1: 56; Supplier 1: Newark; Supplier Part Number 1: 12P4257; Width: 3.9mm
U3 = NEO-7M-0: series RF Receiver GLONASS, GNSS, GPS 1.575GHz -161dBm - - -; Manufacturer: u-blox; Interface: I2C,SPI,USB,UART; Case/Package: SMD/SMT; Category: RF Receivers, Transceivers; Component Kind: Standard; ComponentLink1Description: https://www.u-blox.com/sites/default/files/products/documents/NEO-7_DataSheet_%28UBX-13003830%29.pdf?src-supplier=Digi-Key; ComponentLink1URL: https://www.u-blox.com/sites/default/files/products/documents/NEO-7_DataSheet_%28UBX-13003830%29.pdf?src-supplier=Digi-Key; Frequency: 1.575GHz; Library Name: MyLib.IntLib; Manufacturer Part Number: NEO-7M-0; Max Operating Temperature: 85°C; Min Operating Temperature: -40°C; Packaging: Tape and Reel; Pricing 1: 1=26.50000, 10=25.70000, 50=21.08000, 100=16.88010 (USD); RoHS: Yes; Sensitivity: -161dBm; Supplier 1: Digi-Key; Supplier Part Number 1: 672-NEO-7M-0CT-ND
U4 = MAX2659ELT+T: IC AMP LOW NOISE 6-UDFN; Manufacturer: Maxim; Frequency: 1.57542GHz; Gain: 20.5dB; Max Frequency: 1.75GHz; Max Power Dissipation: 167mW; Max Supply Voltage: 3.6V; Min Supply Voltage: 1.6V; Noise Figure: 0.8dB; Number of Channels: 1; Operating Frequency: 1 GHz to 2 GHz; Operating Supply Current: 4.1mA; Operating Supply Voltage: 2.85V; P1dB: -12dBm; Amplifier Type: LOW NOISE; Case/Package: WFDFN; Category: RF Amplifiers; Component Kind: Standard; ComponentLink1Description: https://datasheet.ciiva.com/16460/max2659-16460138.pdf?src-supplier=Avnet+Asia; ComponentLink1URL: https://datasheet.ciiva.com/16460/max2659-16460138.pdf?src-supplier=Avnet+Asia; ComponentLink2Description: https://datasheet.ciiva.com/26924/max2659elt-t-26924805.pdf?src-supplier=IIIC; ComponentLink2URL: https://datasheet.ciiva.com/26924/max2659elt-t-26924805.pdf?src-supplier=IIIC; ComponentLink3Description: https://datasheet.ciiva.com/4915/max2659-74449-4915373.pdf?src-supplier=Mouser; ComponentLink3URL: https://datasheet.ciiva.com/4915/max2659-74449-4915373.pdf?src-supplier=Mouser; ComponentLink4Description: https://datasheet.ciiva.com/3301/max2659-3301469.pdf?src-supplier=Avnet; ComponentLink4URL: https://datasheet.ciiva.com/3301/max2659-3301469.pdf?src-supplier=Avnet; ComponentLink5Description: https://datasheet.ciiva.com/2790/max2659-2790456.pdf?src-supplier=Digi-Key; ComponentLink5URL: https://datasheet.ciiva.com/2790/max2659-2790456.pdf?src-supplier=Digi-Key; ComponentLink6Description: https://datasheet.ciiva.com/2790/max2659-2790457.pdf?src-supplier=Digi-Key; ComponentLink6URL: https://datasheet.ciiva.com/2790/max2659-2790457.pdf?src-supplier=Digi-Key; ComponentLink7Description: https://datasheet.ciiva.com/2790/max2659-2790455.pdf?src-supplier=Digi-Key; ComponentLink7URL: https://datasheet.ciiva.com/2790/max2659-2790455.pdf?src-supplier=Digi-Key; Height: 0.75mm; Lead Free: Lead Free; Length: 1.55mm; Library Name: My_SCH_Lib.SchLib; Manufacturer Part Number: MAX2659ELT+T; Max Operating Temperature: 85°C; Min Operating Temperature: -40°C; Mount: Surface Mount; Number of Pins: 6; Package Quantity: 2500; Packaging: Tape and Reel; Pricing 1: 1=1.93000, 10=1.75000, 50=1.65000, 100=1.40000, 1000=0.95300, 10000=0.89800 (USD); RoHS: Yes; RoHS Compliant: Yes; Series: -; Stock 1: 13622; Supplier 1: Mouser; Supplier Part Number 1: 700-MAX2659ELT+T; Test Frequency: 1.57542GHz; Width: 1.05mm
USB1 = 10103592-0001LF: CONN USB MICRO B RECPT SMT R/A; Manufacturer: ICC; Category: USB Connectors; Component Kind: Standard; Library Name: My_SCH_Lib.SchLib; Manufacturer Part Number: 10103592-0001LF; Pricing 1: 1=0.76000, 10=0.66700, 25=0.62720, 50=0.60120, 100=0.57510, 250=0.52276, 500=0.47050 (USD); RoHS: No; Stock 1: 48303; Supplier 1: Digi-Key; Supplier Part Number 1: 609-4048-1-ND; Weight: 0.31
